# Altium schematic sheet Inputs Outputs.SchDoc("Inputs Outputs")
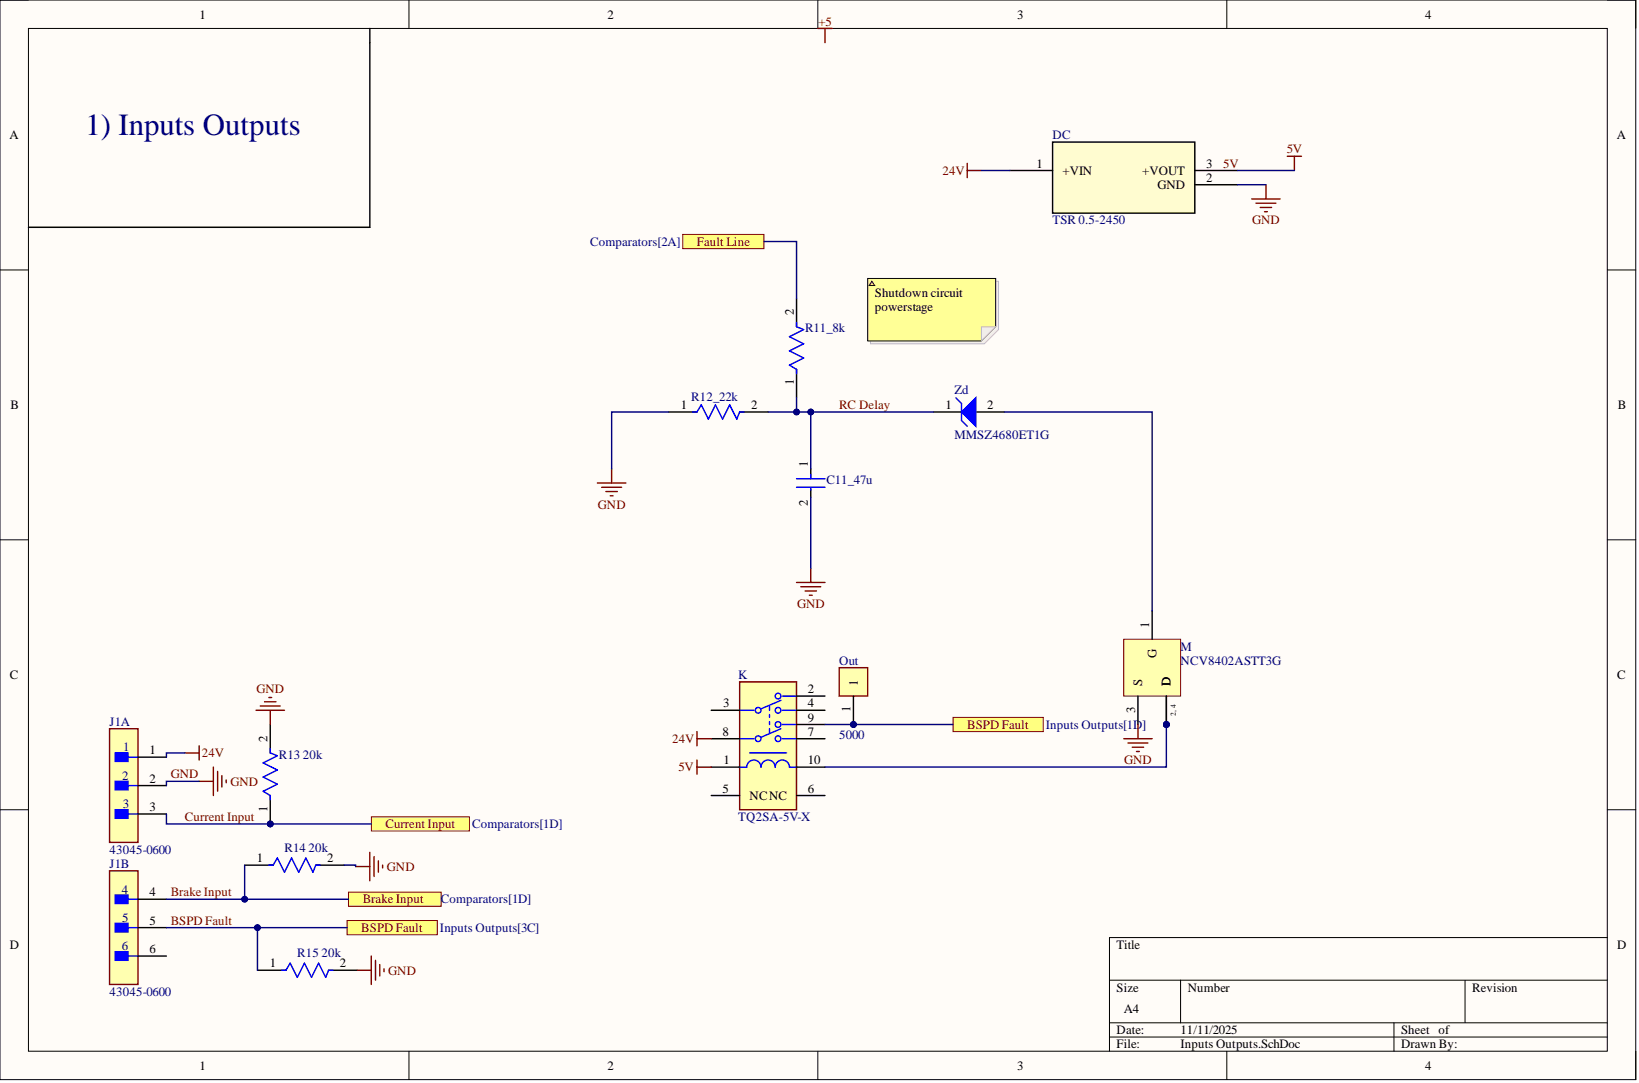
[diagram p0: Inputs Outputs.SchDoc]

## Parts
C11_47u  GRM188R60J476ME15D  (value not drawn)
DC  TSR 0.5-2450  Nominal Output Voltage: 5V
J1  43045-0600  (parts A,B)
K  TQ2SA-5V-X  Coil Voltage (DC): 5V
M  NCV8402ASTT3G
Out  5000
R11_8k  MCA12060D8001BP500  (value not drawn)
R12_22k  CRGCQ1206F22K  (value not drawn)
R13_20k  CRCW120620K0FKEA  (value not drawn)
R14_20k  CRCW120620K0FKEA  (value not drawn)
R15_20k  CRCW120620K0FKEA  (value not drawn)
Zd  MMSZ4680ET1G

## Ports
Brake Input, BSPD Fault, Current Input, Fault Line

## Nets
24V: DC.1 J1.1 K.8
5V: DC.3 K.1
Brake Input: J1.4 R14_20k.1 ; port Brake Input
BSPD Fault: J1.5 K.9 Out.1 R15_20k.1 ; port BSPD Fault
Current Input: J1.3 R13_20k.1 ; port Current Input
Fault Line: R11_8k.2 ; port Fault Line
GND: C11_47u.2 DC.2 J1.2 M.3(S) R12_22k.1 R13_20k.2 R14_20k.2 R15_20k.2
RC Delay: C11_47u.1 R11_8k.1 R12_22k.2 Zd.1
NetK_10: K.10 M.2(D) M.4
NetM_1: M.1(G) Zd.2

Not connected: J1 6; K 2 3 4 5(NC) 6(NC) 7

## Library data of the parts
C11_47u = CMP-2000-05444-2: Chip Multilayer Ceramic Capacitors for General Purpose, 0603, 47uF, X5R, 15%, 20%, 6.3V; Capacitance: 47uF; Case Code (Imperial): 0603; Case Code (Metric): 1608; Case/Package: 0603; Component Kind: Standard; Dielectric: X5R; Length: 0.063inch; Library Name: Altium Content Vault; Max Operating Temperature: 85°C; Min Operating Temperature: -55°C; Mount: Surface Mount; Packaging: Tape and Reel; Thickness: 0.039inch; Tolerance: 20%; Voltage Rating: 6.3V; Voltage Rating (DC): 6.3V; Width: 0.031inch
DC = CMP-01539-47767759-1: TSR 0.5-2450 DC-DC Converter Switching Regulator, 6.5-32VDC Input, 5VDC, 0.5A, TSR 0.5 Series; Efficiency: 94%; Max Input Voltage: 32V; Max Output Current: 500mA; Max Output Power: 4W; Min Input Voltage: 6.5V; Number of Outputs: 1; Case/Package: SIP; Component Kind: Standard; Depth: 10.2mm; Length: 11.5mm; Library Name: Altium Content Vault; Max Input Voltage (DC): 32V; Max Operating Temperature: 90°C; Min Input Voltage (DC): 6.5V; Min Operating Temperature: -40°C; Mount: Through Hole; NexarPartId: 47767759; Output Current: 500mA; Output Power: 4W; Output Voltage: 5V; REACH SVHC: Unknown; RoHS: Compliant; Weight: 1.95g; Width: 7.55mm
J1 = CMP-00257-00287064-1: 43045-0600 Connector Wire to Board Header 6 Position 3mm Solder Right Angle Thru-Hole Tray; Manufacturer Lifecycle Status: ACTIVE; NexarPartId: 287064; Approvals: CSA, TUV, UL; Contact Resistance: 10mΩ; Current Rating: 5A; Gender: Male; Glow Wire Compliant: Compliant; Insulation Resistance: 1GΩ; Max Voltage Rating (DC): 250.25kV; Number of Circuits: 6; Plating: Tin; Voltage Rating: 250V; Case/Package: SMC; Color: Black; Component Kind: Standard; Contact Material: Brass, Tin; Contact Plating: Tin; Country of Origin: US; Depth: 12.2mm; Diameter: 3mm; Flammability Rating: UL94 V-0; Halogen Free: Low Halogen; Height: 7.37mm; Housing Color: Black; Housing Material: Thermoplastic; Lead Free: Lead Free; Lead Pitch: 3mm; Length: 12.6mm; Library Name: Altium Content Vault; Lifecycle Status: Production; Material: Polyester; Max Current Rating: 5A; Max Operating Temperature: 105°C; Min Operating Temperature: -40°C; Mount: Through Hole; Number of Contacts: 6; Number of Pins: 6; Number of Positions: 6; Number of Rows: 2; Orientation: Right Angle, Vertical; Packaging: Bulk; Pitch: 3mm; Radiation Hardening: No; REACH SVHC: No SVHC; RoHS: Compliant; Row Spacing: 3mm; Schedule B: 8536694040; Termination: Solder; Voltage Rating (AC): 250V; Voltage Rating (DC): 250V; Width: 9.9mm
K = CMP-00287-00689023-1: TQ2SA-5V-X Electromechanical Relay DPDT 2A 5VDC 178Ohm Surface Mount; NexarPartId: 689023; Coil Current: 28.1mA; Coil Power: 140mW; Coil Resistance: 178Ω; Coil Type: Non-Latching; Insertion Loss (dB): 0.8dB; Max Voltage Rating (AC): 125V; Max Voltage Rating (DC): 220V; Number of Contacts: 2; Operate Time: 4ms; Relay Type: Telecom; Ambient Temperature Range High: 85°C; Component Kind: Standard; Contact Current Rating: 2A; Contact Material: Gold, Silver; Current Rating: 2A; Depth: 11.5mm; Height: 5.9mm; Lead Free: Lead Free; Length: 14mm; Library Name: Altium Content Vault; Max Current Rating: 2A; Max Operating Temperature: 85°C; Min Operating Temperature: -40°C; Mount: Surface Mount; Number of Pins: 8; Packaging: Tape & Reel (TR); Power Consumption: 140mW; Release Time: 4ms; RoHS: Compliant; Switching Current: 2A; Termination: Gull Wing; Throw Configuration: DPDT; Voltage Rating (AC): 125V; Weight: 2g; Width: 9mm
M = CMP-1069-00020-1: Self-Protected Low Side Driver with Temperature and Current Limit, 4-Pin SOT-223, Pb-Free, Tape and Reel; Manufacturer: On Semiconductor; Channel Polarity: N Channel; Component Kind: Standard; ComponentLink1Description: Manufacturer URL; ComponentLink1URL: http://www.onsemi.com/; ComponentLink2Description: Datasheet; ComponentLink2URL: http://www.onsemi.com/pub_link/Collateral/NCV8402-D.PDF; ComponentLink3Description: Package Specification; ComponentLink3URL: http://www.onsemi.com/pub_link/Collateral/318E-04.PDF; DatasheetVersion: Rev. 8; Library Name: Altium Content Vault; Mounting Technology: Surface Mount; PackageDescription: 4-Pin Small Outline Transistor, Body 6.5 x 3.5 mm, Pitch 2.3 mm; PackageReference: SOT-223-4-318E-04; PackageVersion: Rev. N, 08/2009; Packing: Tape and Reel; PartNumber: NCV8402ASTT3G; RoHS: Pb-Free
Out = CMP-19636-000027-1: PC TEST POINT MINIATURE RED; Body Material: Bronze; Hole Diameter: 1.6mm; Inside Diameter: 1.27mm; Insulation: Insulated; Number of Contacts: 1; Outside Diameter: 2.54mm; Plating: Silver; Color: Red; Component Kind: Standard; Contact Material: Bronze; Contact Plating: Tin; Flammability Rating: UL94 V-0; Height: 0.3inch; Housing Color: Red; Lead Free: Lead Free; Length: 7.62mm; Library Name: Altium Content Vault; Material: Nylon; Mount: PCB; Number of Ports: 1; Orientation: Straight; Package Quantity: 100; Packaging: Bulk; REACH SVHC: No SVHC; RoHS Compliant: Yes; Series: THM
R11_8k = CMP-02407-011756-1: Res MC Precision Thin Film 1206 8kΩ 0.1% 0.25W ±25ppm/°C Molded Paper T/R; Max Power Dissipation: 250mW; Resistance: 8kR; Case/Package: 1206; Component Kind: Standard; Construction: Rectangular; Depth: 1.6mm; Height: 0.026inch; Lead Free: Lead Free; Length: 0.126inch; Library Name: Altium Content Vault; Max Operating Temperature: 125°C; Military Standard: Not; Min Operating Temperature: -55°C; Number of Terminations: 2; Package Quantity: 5000; Packaging: Tape and Reel; Resistor Type: Thin Film; RoHS Compliant: Yes; Series: MCA 1206 - Precision; Temperature Coefficient: 25ppm/°C; Tolerance: 0.1%; Width: 0.063inch
R12_22k = CMP-03211-003620-1: CRGCQ1206 22KΩ 0.25W 1% ±100ppm/°C 200V; Manufacturer: TE Connectivity; Automotive Grade: Grade 985; Tolerance: 0.01; Ambient Temperature Range High: +70°C; Automotive: Yes; Category: Res; Component Kind: Standard; ComponentLink2Description: Datasheet; ComponentLink2URL: https://www.te.com/commerce/DocumentDelivery/DDEController?Action=showdoc&DocId=Data+Sheet%7F1773204-3%7F1%7Fpdf%7FEnglish%7FENG_DS_1773204-3_1.pdf%7F4-2176347-994; Composition: Thick Film; Device Class L1: Passive Components; Device Class L2: Resistors; Device Class L3: Chip SMD Resistors; Height: 0.65mm; Ipc Land Pattern Name: RESC310155X55; Lead Free: Yes; Library Name: Altium Content Vault; Manufacturer Part Number: CRGCQ1206F22K; Octopart: https://octopart.com/crgcq1206f22k-te+connectivity-91018265; Package: CRGCQ1206; Power Rating: 0.25W; Resistance: 22KΩ; RoHS: TRUE; Series: CRGCQ; Temperature: -55°C to +155°C; Temperature Coefficient: ±100ppm/°C; Temperature Range High: +155°C; Temperature Range Low: -55°C; Value: 22KΩ; Voltage Rating: 200V
R13_20k R14_20k R15_20k = CMP-2003-01940-2: RES Thick Film, 20kΩ, 1%, 0.25W, 100ppm/°C, 1206; Max Power Dissipation: 250mW; Resistance: 20kR; Voltage Rating: 200V; Case Code (Imperial): 1206; Case Code (Metric): 3216; Case/Package: 1206; Component Kind: Standard; Composition: Thick Film; Contact Plating: Tin; Depth: 1.6mm; Features: Automotive AEC-Q200; Height: 0.55mm; Lead Free: Lead Free; Length: 3.2mm; Library Name: Altium Content Vault; Max Operating Temperature: 155°C; Military Standard: Not; Min Operating Temperature: -55°C; Mount: Surface Mount; Number of Pins: 2; Number of Terminations: 2; Package Quantity: 10; Packaging: Tape and Reel; Power Rating: 250mW; Radiation Hardening: No; Temperature Coefficient: 100ppm/°C; Termination: SMD/SMT; Tolerance: 1%; Voltage Rating (DC): 200V; Weight: 0.000353oz; Width: 0.063inch; Working Voltage: 200V
Zd = CMP-1060-00608-1: Zener Voltage Regulator, 500 mW, 2-Pin SOD-123, Pb-Free, Tape and Reel; Manufacturer: On Semiconductor; P Max (W): 0.5; VZ Typ (V): 2.2; Component Kind: Standard; ComponentLink1Description: Manufacturer URL; ComponentLink1URL: http://www.onsemi.com/; ComponentLink2Description: Datasheet; ComponentLink2URL: http://www.onsemi.com/pub_link/Collateral/MMSZ4678ET1-D.PDF; ComponentLink3Description: Package Specification; ComponentLink3URL: http://www.onsemi.com/pub_link/Collateral/425-04.PDF; DatasheetVersion: Rev. 6; Library Name: Altium Content Vault; Mounting Technology: Surface Mount; PackageDescription: 2-Pin SOD, Body 1.6 x 2.69 mm; PackageReference: SOD-123-2-425-04; PackageVersion: Rev. G, 10/2009; Packing: Tape and Reel; PartNumber: MMSZ4680ET1G; RoHS: Pb-Free
